# Altium schematic sheet Sheet1.SchDoc(Sheet1)
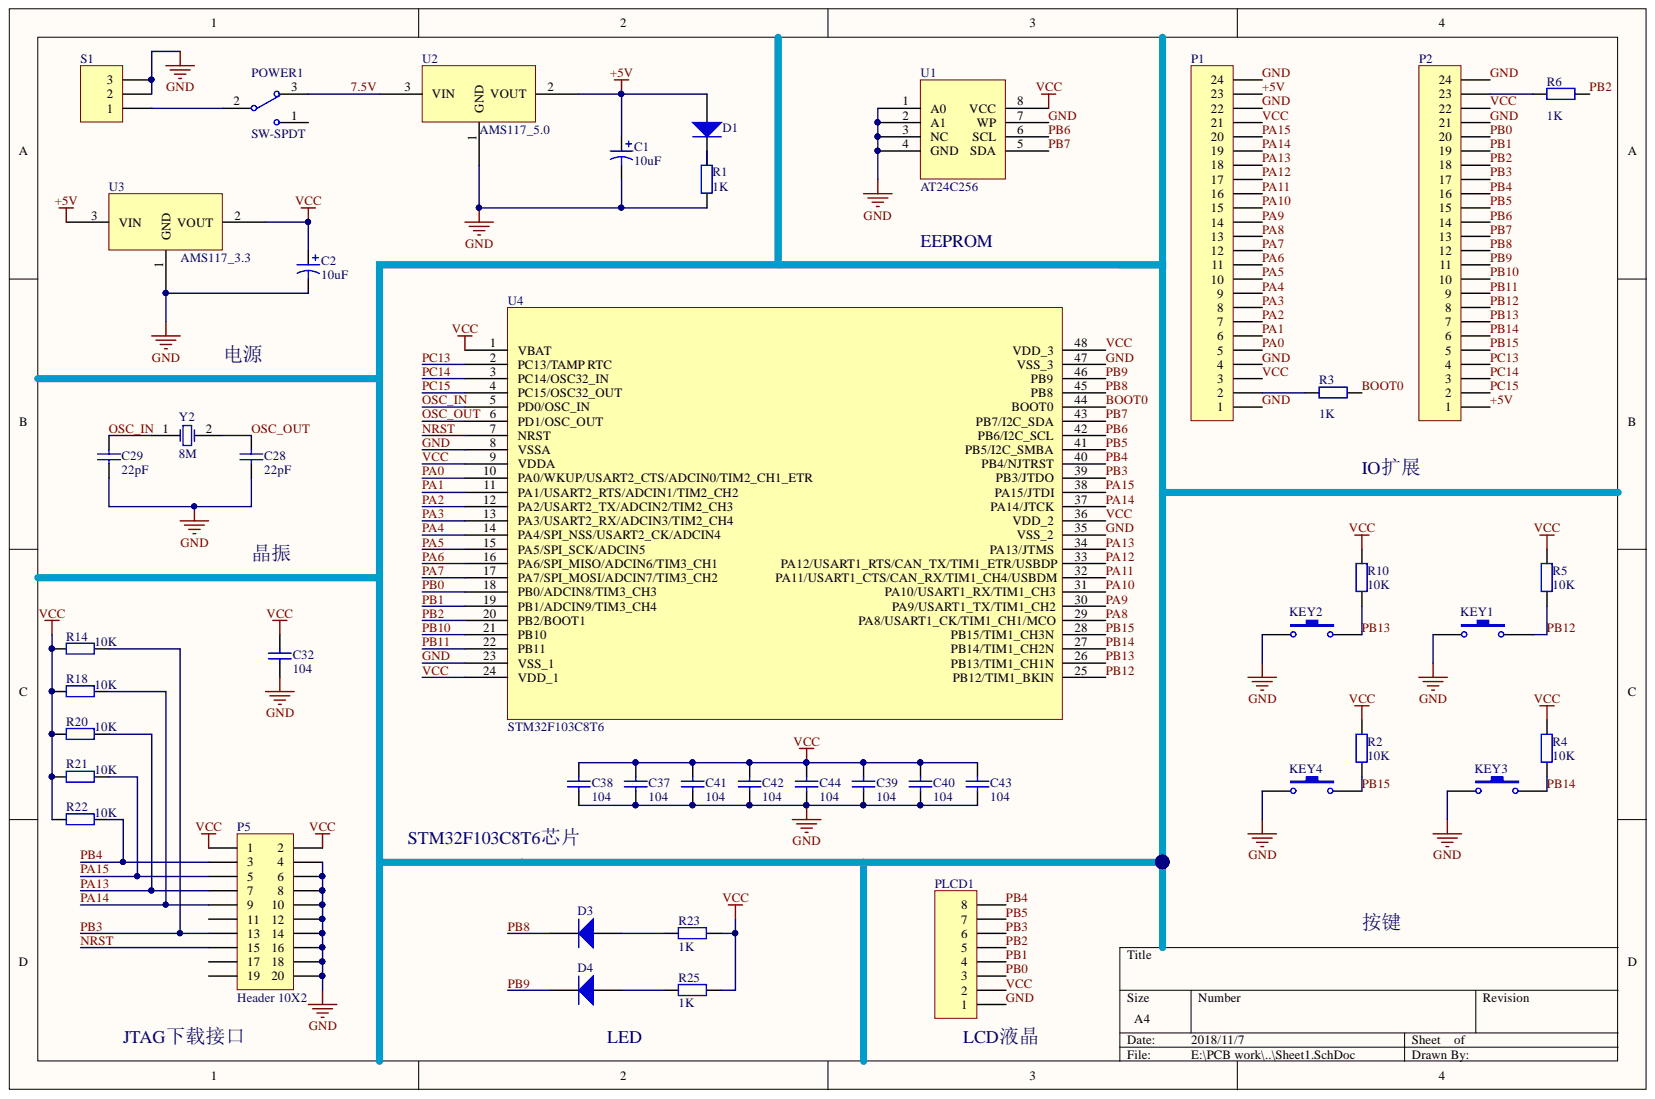
[diagram p0: Sheet1.SchDoc]

## Parts
C1  10uF  [1206]
C2  10uF  [1206]
C28  value 22pF  [0603]  (hidden comment: Cap)
C29  value 22pF  [0603]  (hidden comment: Cap)
C32  value 104  [0603]  (hidden comment: Cap)
C37  value 104  [0603]  (hidden comment: Cap)
C38  value 104  [0603]  (hidden comment: Cap)
C39  value 104  [0603]  (hidden comment: Cap)
C40  value 104  [0603]  (hidden comment: Cap)
C41  value 104  [0603]  (hidden comment: Cap)
C42  value 104  [0603]  (hidden comment: Cap)
C43  value 104  [0603]  (hidden comment: Cap)
C44  value 104  [0603]  (hidden comment: Cap)
D1  Diode  [0805]  (value not drawn)
D3  Diode  [0805]  (value not drawn)
D4  Diode  [0805]  (value not drawn)
KEY1  SW-PB  [KEY_RST]  (value not drawn)
KEY2  SW-PB  [KEY_RST]  (value not drawn)
KEY3  SW-PB  [KEY_RST]  (value not drawn)
KEY4  SW-PB  [KEY_RST]  (value not drawn)
P1  Header 24  [HDR1X24]  (value not drawn)
P2  Header 24  [HDR1X24]  (value not drawn)
P5  Header 10X2  [HEAD2*10]
PLCD1  Header 8  [LCD2.4_1]  (value not drawn)
POWER1  SW-SPDT  [KEY_12F23_keepoutlayer]
R1  value 1K  [0603]  (hidden comment: Res2)
R2  value 10K  [0603]  (hidden comment: Res2)
R3  value 1K  [0603]  (hidden comment: Res2)
R4  value 10K  [0603]  (hidden comment: Res2)
R5  value 10K  [0603]  (hidden comment: Res2)
R6  value 1K  [0603]  (hidden comment: Res2)
R10  value 10K  [0603]  (hidden comment: Res2)
R14  value 10K  [0603]  (hidden comment: Res2)
R18  value 10K  [0603]  (hidden comment: Res2)
R20  value 10K  [0603]  (hidden comment: Res2)
R21  value 10K  [0603]  (hidden comment: Res2)
R22  value 10K  [0603]  (hidden comment: Res2)
R23  value 1K  [0603]  (hidden comment: Res2)
R25  value 1K  [0603]  (hidden comment: Res2)
S1  Header 3  [DC005_KLD-0202]  (value not drawn)
U1  AT24C256  [AT24C256_SOP8]
U2  AMS117_5.0
U3  AMS117_3.3
U4  STM32F103C8T6
Y2  8M  [CRYSTAL_2DIP]

## Nets
7.5V: POWER1.3 U2.3
+5V: C1.1 D1.1 P1.23 P2.1 U2.2 U3.3
BOOT0: R3.2 U4.44(BOOT0)
GND: C1.2 C2.2 C28.1 C29.1 C32.1 C37.1 C38.1 C39.1 C40.1 C41.1 C42.1 C43.1 C44.1 KEY1.1 KEY2.1 KEY3.1 KEY4.1 P1.1 P1.4 P1.22 P1.24 P2.21 P2.24 P5.4 P5.6 P5.8 P5.10 P5.12 P5.14 P5.16 P5.18 P5.20 PLCD1.1 R1.2 S1.2 S1.3 U1.1(A0) U1.2(A1) U1.3(NC) U1.4(GND) U1.7(WP) U2.1 U3.1 U4.8(VSSA) U4.23(VSS_1) U4.35(VSS_2) U4.47(VSS_3)
NRST: P5.15 U4.7(NRST)
OSC_IN: C29.2 U4.5(PD0/OSC_IN) Y2.1
OSC_OUT: C28.2 U4.6(PD1/OSC_OUT) Y2.2
PA0: P1.5 U4.10(PA0/WKUP/USART2_CTS/ADCIN0/TIM2_CH1_ETR)
PA1: P1.6 U4.11(PA1/USART2_RTS/ADCIN1/TIM2_CH2)
PA2: P1.7 U4.12(PA2/USART2_TX/ADCIN2/TIM2_CH3)
PA3: P1.8 U4.13(PA3/USART2_RX/ADCIN3/TIM2_CH4)
PA4: P1.9 U4.14(PA4/SPI_NSS/USART2_CK/ADCIN4)
PA5: P1.10 U4.15(PA5/SPI_SCK/ADCIN5)
PA6: P1.11 U4.16(PA6/SPI_MISO/ADCIN6/TIM3_CH1)
PA7: P1.12 U4.17(PA7/SPI_MOSI/ADCIN7/TIM3_CH2)
PA8: P1.13 U4.29(PA8/USART1_CK/TIM1_CH1/MCO)
PA9: P1.14 U4.30(PA9/USART1_TX/TIM1_CH2)
PA10: P1.15 U4.31(PA10/USART1_RX/TIM1_CH3)
PA11: P1.16 U4.32(PA11/USART1_CTS/CAN_RX/TIM1_CH4/USBDM)
PA12: P1.17 U4.33(PA12/USART1_RTS/CAN_TX/TIM1_ETR/USBDP)
PA13: P1.18 P5.7 R20.2 U4.34(PA13/JTMS)
PA14: P1.19 P5.9 R18.2 U4.37(PA14/JTCK)
PA15: P1.20 P5.5 R21.2 U4.38(PA15/JTDI)
PB0: P2.20 PLCD1.3 U4.18(PB0/ADCIN8/TIM3_CH3)
PB1: P2.19 PLCD1.4 U4.19(PB1/ADCIN9/TIM3_CH4)
PB2: P2.18 PLCD1.5 R6.2 U4.20(PB2/BOOT1)
PB3: P2.17 P5.13 PLCD1.6 R14.2 U4.39(PB3/JTDO)
PB4: P2.16 P5.3 PLCD1.8 R22.2 U4.40(PB4/NJTRST)
PB5: P2.15 PLCD1.7 U4.41(PB5/I2C_SMBA)
PB6: P2.14 U1.6(SCL) U4.42(PB6/I2C_SCL)
PB7: P2.13 U1.5(SDA) U4.43(PB7/I2C_SDA)
PB8: D3.2 P2.12 U4.45(PB8)
PB9: D4.2 P2.11 U4.46(PB9)
PB10: P2.10 U4.21(PB10)
PB11: P2.9 U4.22(PB11)
PB12: KEY1.2 P2.8 R5.2 U4.25(PB12/TIM1_BKIN)
PB13: KEY2.2 P2.7 R10.2 U4.26(PB13/TIM1_CH1N)
PB14: KEY3.2 P2.6 R4.2 U4.27(PB14/TIM1_CH2N)
PB15: KEY4.2 P2.5 R2.2 U4.28(PB15/TIM1_CH3N)
PC13: P2.4 U4.2(PC13/TAMP_RTC)
PC14: P2.3 U4.3(PC14/OSC32_IN)
PC15: P2.2 U4.4(PC15/OSC32_OUT)
VCC: C2.1 C32.2 C37.2 C38.2 C39.2 C40.2 C41.2 C42.2 C43.2 C44.2 P1.3 P1.21 P2.22 P5.1 P5.2 PLCD1.2 R2.1 R4.1 R5.1 R10.1 R14.1 R18.1 R20.1 R21.1 R22.1 R23.2 R25.2 U1.8(VCC) U3.2 U4.1(VBAT) U4.9(VDDA) U4.24(VDD_1) U4.36(VDD_2) U4.48(VDD_3)
NetD1_2: D1.2 R1.1
NetD3_1: D3.1 R23.1
NetD4_1: D4.1 R25.1
NetP1_2: P1.2 R3.1
NetP2_23: P2.23 R6.1
NetPOWER1_2: POWER1.2 S1.1
N00005:

Not connected: P5 11 17 19; POWER1 1

## Library data of the parts
C1 C2 = Cap Pol1: Polarized Capacitor (Radial); Component Kind: Standard; LatestRevisionDate: 17-Jul-2002; LatestRevisionNote: Re-released for DXP Platform.; Library Name: Miscellaneous Devices.IntLib; PackageDescription: Polarized Capacitor (Radial); 2 Leads; PackageReference: RB7.6-15; Published: 8-Jun-2000; Signal Integrity: Cap Pol; Simulation: CAP; Value: 10uF; Publisher: Altium Limited
C28 C29 C32 C37-C44 = Cap: Capacitor; Component Kind: Standard; LatestRevisionDate: 17-Jul-2002; LatestRevisionNote: Re-released for DXP Platform.; Library Name: Miscellaneous Devices.IntLib; PackageDescription: Radial Cap, Thru-Hole; 2 Leads; 0.3 in Pin Spacing; PackageReference: RAD-0.3; Published: 8-Jun-2000; Signal Integrity: Cap; Simulation: CAP; Publisher: Altium Limited
D1 D3 D4 = Diode: Default Diode; Code_JEDEC: DO-214-AB; Component Kind: Standard; LatestRevisionDate: 17-Jul-2002; LatestRevisionNote: Re-released for DXP Platform.; Library Name: Miscellaneous Devices.IntLib; PackageDescription: DO-214-AB/SMC; 2 C-Bend Leads; Body 7.9 x 5.9 mm, inc. leads (LxW); PackageReference: SMC; PackageVersion: Sep-1996; Published: 8-Jun-2000; Signal Integrity: Diode; Simulation: DIODE; Publisher: Altium Limited
KEY1-KEY4 = SW-PB: Switch; Component Kind: Standard; LatestRevisionDate: 17-Jul-2002; LatestRevisionNote: Re-released for DXP Platform.; Library Name: Miscellaneous Devices.IntLib; PackageDescription: Switch; 2 Leads; PackageReference: SPST-2; Published: 24-Mar-1999; Publisher: Altium Limited
P1 P2 = Header 24: Header, 24-Pin; Component Kind: Standard; LatestRevisionDate: 17-Jul-2002; LatestRevisionNote: Re-released for DXP Platform.; Library Name: Miscellaneous Connectors.IntLib; Signal Integrity: Connector; Publisher: Altium Limited
P5 = Header 10X2: Header, 10-Pin, Dual row; Component Kind: Standard; LatestRevisionDate: 17-Jul-2002; LatestRevisionNote: Re-released for DXP Platform.; Library Name: Miscellaneous Connectors.IntLib; Signal Integrity: Connector; Publisher: Altium Limited
PLCD1 = Header 8: Header, 8-Pin; Component Kind: Standard; LatestRevisionDate: 17-Jul-2002; LatestRevisionNote: Re-released for DXP Platform.; Library Name: Miscellaneous Connectors.IntLib; Signal Integrity: Connector; Publisher: Altium Limited
POWER1 = SW-SPDT: SPDT Subminiature Toggle Switch, Right Angle Mounting, Vertical Actuation; Component Kind: Standard; ComponentLink1Description: Manufacturer Link; ComponentLink1URL: http://www.apem.com; DatasheetVersion: 05-Dec-2000; LatestRevisionDate: 17-Dec-2003; LatestRevisionNote: Schematic revised, PCB Footprint replaced.; Library Name: Miscellaneous Devices.IntLib; PackageDescription: Switch; 4 Leads; PackageReference: TL36WW15050; Published: 24-Mar-1999; Publisher: Altium Limited
R1-R6 R10 R14 R18 R20-R23 R25 = Res2: Resistor; Component Kind: Standard; LatestRevisionDate: 17-Jul-2002; LatestRevisionNote: Re-released for DXP Platform.; Library Name: Miscellaneous Devices.IntLib; PackageDescription: Axial Device, Thru-Hole; 2 Leads; 0.4 in Pin Spacing; PackageReference: AXIAL-0.4; Published: 8-Jun-2000; Signal Integrity: Res; Simulation: RESISTOR; Publisher: Altium Limited
S1 = Header 3: Header, 3-Pin; Component Kind: Standard; LatestRevisionDate: 17-Jul-2002; LatestRevisionNote: Re-released for DXP Platform.; Library Name: Miscellaneous Connectors.IntLib; Signal Integrity: Connector; Publisher: Altium Limited
U2 U3 = AMS117: Component Kind: Standard; Library Name: 2. POWER.SchLib
Y2 = XTAL: Crystal Oscillator; Component Kind: Standard; LatestRevisionDate: 17-Jul-2002; LatestRevisionNote: Re-released for DXP Platform.; Library Name: Miscellaneous Devices.IntLib; PackageDescription: Crystal, Thru-Hole; 2 Leads; Body 3.1 x 8.2 mm (Dia.xH); PackageReference: R38; Published: 8-Jun-2000; Simulation: XTAL; Publisher: Altium Limited
U1 = AT24C256: Component Kind: Standard; Library Name: 3. MEMORY.SchLib
U4 = STM32F103C8T6: Component Kind: Standard; Library Name: 1.MCU.SchLib
